# Altium schematic sheet power.SchDoc(power)
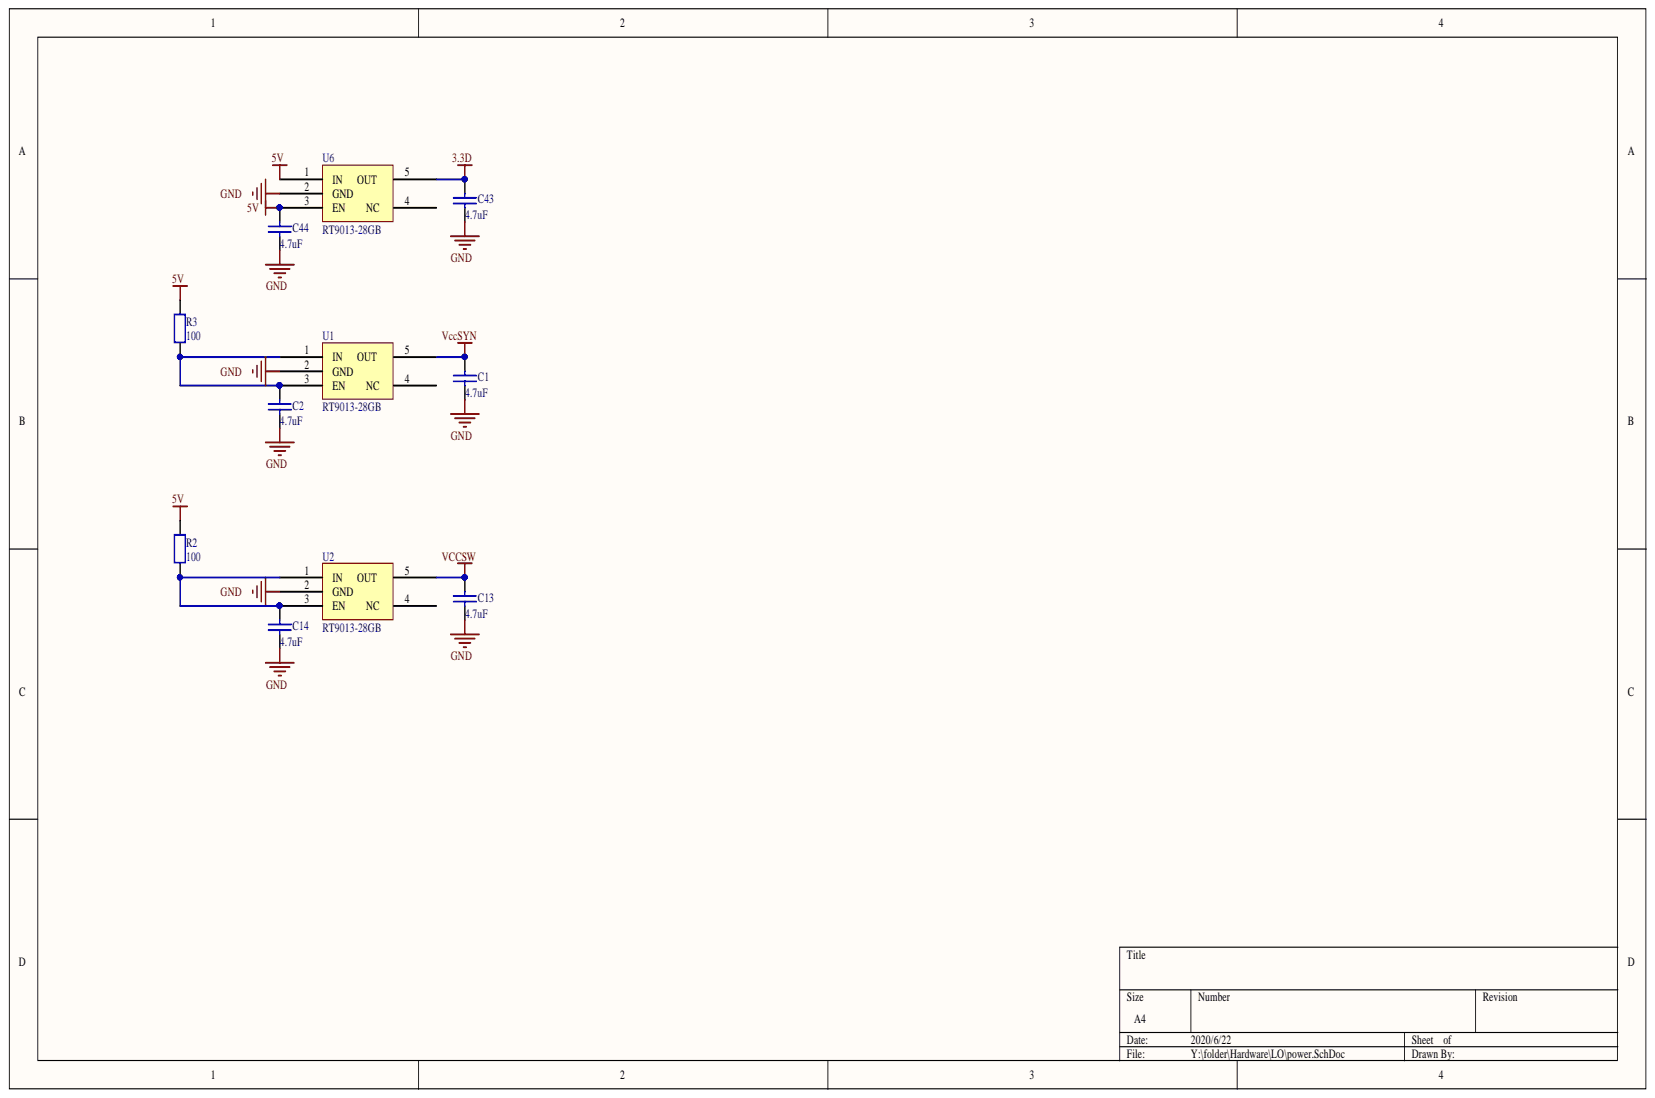
[diagram p0: power.SchDoc]

## Parts
C1  4.7uF  [0603]
C2  4.7uF  [0603]
C13  4.7uF  [0603]
C14  4.7uF  [0603]
C43  4.7uF  [0603]
C44  4.7uF  [0603]
R2  100  [0603]
R3  100  [0603]
U1  RT9013-28GB  [SOT23-5]
U2  RT9013-28GB  [SOT23-5]
U6  RT9013-28GB  [SOT23-5]

## Nets
3.3D: C43.2 U6.5(OUT)
5V: C44.2 R2.2 R3.2 U6.1(IN) U6.3(EN)
GND: C1.1 C2.1 C13.1 C14.1 C43.1 C44.1 U1.2(GND) U2.2(GND) U6.2(GND)
VCCSW: C13.2 U2.5(OUT)
VccSYN: C1.2 U1.5(OUT)
NetC2_2: C2.2 R3.1 U1.1(IN) U1.3(EN)
NetC14_2: C14.2 R2.1 U2.1(IN) U2.3(EN)

Not connected: U1 4(NC); U2 4(NC); U6 4(NC)

## Library data of the parts
C1 C2 C13 C14 C43 C44 = Cap: Capacitor; Simulation: CAP; Signal Integrity: Cap; Published: 8-Jun-2000; PackageReference: RAD-0.3; PackageDescription: Radial Cap, Thru-Hole; 2 Leads; 0.3 in Pin Spacing; Library Name: RTC6705.SchLib; LatestRevisionNote: Re-released for DXP Platform.; LatestRevisionDate: 17-Jul-2002; Component Kind: Standard; Publisher: Altium Limited
R2 R3 = Res2: Resistor; Value: 0Ohm; Simulation: RESISTOR; Signal Integrity: Res; Published: 8-Jun-2000; PackageReference: AXIAL-0.4; PackageDescription: Axial Device, Thru-Hole; 2 Leads; 0.4 in Pin Spacing; Library Name: Miscellaneous Devices.IntLib; LatestRevisionNote: Re-released for DXP Platform.; LatestRevisionDate: 17-Jul-2002; Component Kind: Standard; Publisher: Altium Limited
U1 U2 U6 = LP5907: Library Name: RTC6705.SchLib; Component Kind: Standard
